# Altium schematic sheet Micro.SchDoc(Micro)
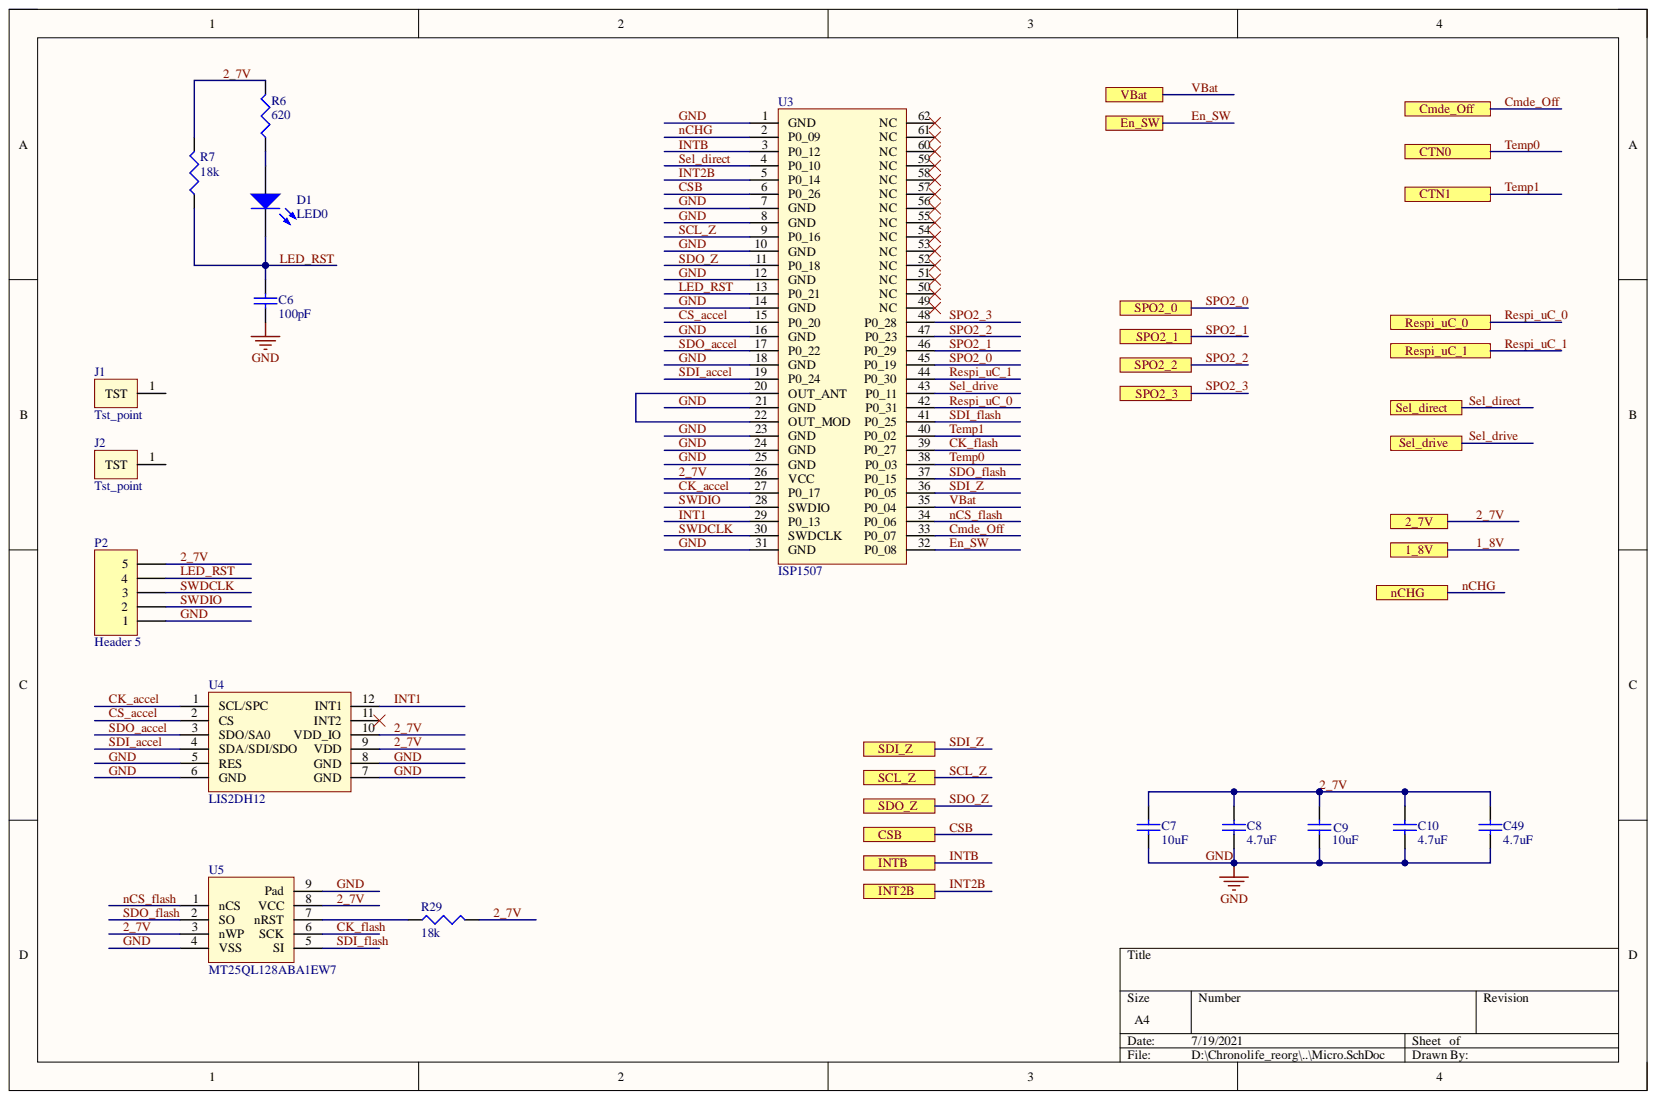
[diagram p0: Micro.SchDoc]

## Parts
C6  100pF  [0402_GC]
C7  10uF  [0402_GC]
C8  4.7uF  [0402_GC]
C9  10uF  [0402_GC]
C10  4.7uF  [0402_GC]
C49  4.7uF  [0402_GC]
D1  LED0  [0603D_GC]
J1  Tst_point  [Mire]
J2  Tst_point  [Mire]
P2  Header 5  [5PADRb_G]
R6  620  [0402_GC]
R7  18k  [0402_GC]
R29  18k  [0402_GC]
U3  ISP1507  [ISP1507]
U4  LIS2DH12  [LGA_12_GC]
U5  MT25QL128ABA1EW7  [WSON_8_8x6]

## Ports
1_8V, 2_7V, Cmde_Off, CSB, CTN0, CTN1, En_SW, INT2B, INTB, nCHG, Respi_uC_0, Respi_uC_1, SCL_Z, SDI_Z, SDO_Z, Sel_direct, Sel_drive, SPO2_0, SPO2_1, SPO2_2, SPO2_3, VBat

## Nets
2_7V: C7.2 C8.2 C9.2 C10.2 C49.2 P2.5 R6.2 R7.2 R29.2 U3.26(VCC) U4.9(VDD) U4.10(VDD_IO) U5.3(nWP) U5.8(VCC) ; port 2_7V
CK_accel: U3.27(P0_17) U4.1(SCL/SPC)
CK_flash: U3.39(P0_27) U5.6(SCK)
Cmde_Off: U3.33(P0_07) ; port Cmde_Off
CS_accel: U3.15(P0_20) U4.2(CS)
CSB: U3.6(P0_26) ; port CSB
En_SW: U3.32(P0_08) ; port En_SW
GND: C6.1 C7.1 C8.1 C9.1 C10.1 C49.1 P2.1 U3.1(GND) U3.7(GND) U3.8(GND) U3.10(GND) U3.12(GND) U3.14(GND) U3.16(GND) U3.18(GND) U3.21(GND) U3.23(GND) U3.24(GND) U3.25(GND) U3.31(GND) U4.5(RES) U4.6(GND) U4.7(GND) U4.8(GND) U5.4(VSS) U5.9(Pad)
INT1: U3.29(P0_13) U4.12(INT1)
INT2B: U3.5(P0_14) ; port INT2B
INTB: U3.3(P0_12) ; port INTB
LED_RST: C6.2 D1.2 P2.4 R7.1 U3.13(P0_21)
nCHG: U3.2(P0_09) ; port nCHG
nCS_flash: U3.34(P0_06) U5.1(nCS)
Respi_uC_0: U3.42(P0_31) ; port Respi_uC_0
Respi_uC_1: U3.44(P0_30) ; port Respi_uC_1
SCL_Z: U3.9(P0_16) ; port SCL_Z
SDI_accel: U3.19(P0_24) U4.4(SDA/SDI/SDO)
SDI_flash: U3.41(P0_25) U5.5(SI)
SDI_Z: U3.36(P0_05) ; port SDI_Z
SDO_accel: U3.17(P0_22) U4.3(SDO/SA0)
SDO_flash: U3.37(P0_15) U5.2(SO)
SDO_Z: U3.11(P0_18) ; port SDO_Z
Sel_direct: U3.4(P0_10) ; port Sel_direct
Sel_drive: U3.43(P0_11) ; port Sel_drive
SPO2_0: U3.45(P0_19) ; port SPO2_0
SPO2_1: U3.46(P0_29) ; port SPO2_1
SPO2_2: U3.47(P0_23) ; port SPO2_2
SPO2_3: U3.48(P0_28) ; port SPO2_3
SWDCLK: P2.3 U3.30(SWDCLK)
SWDIO: P2.2 U3.28(SWDIO)
Temp0: U3.38(P0_03) ; port CTN0
Temp1: U3.40(P0_02) ; port CTN1
VBat: U3.35(P0_04) ; port VBat
NetD1_1: D1.1 R6.1
NetR29_1: R29.1 U5.7(nRST)
NetU3_20: U3.20(OUT_ANT) U3.22(OUT_MOD)
1_8V: ; port 1_8V

Not connected: J1 1; J2 1; U3 49(NC) 50(NC) 51(NC) 52(NC) 53(NC) 54(NC) 55(NC) 56(NC) 57(NC) 58(NC) 59(NC) 60(NC) 61(NC) 62(NC); U4 11(INT2)

## Library data of the parts
C6-C10 C49 = Cap: Capacitor; Component Kind: Standard; LatestRevisionDate: 17-Jul-2002; LatestRevisionNote: Re-released for DXP Platform.; Library Name: Miscellaneous Devices.IntLib; PackageDescription: Radial Cap, Thru-Hole; 2 Leads; 0.3 in Pin Spacing; PackageReference: RAD-0.3; Published: 8-Jun-2000; Signal Integrity: Cap; Simulation: CAP; Publisher: Altium Limited
  C6: Value: 100pF
  C7 C9: Value: 10uF
  C8 C10 C49: Value: 4.7uF
D1 = LED0: Typical INFRARED GaAs LED; Component Kind: Standard; LatestRevisionDate: 08-Jul-2005; LatestRevisionNote: Mech Layer 1 removed.; Library Name: Miscellaneous Devices.IntLib; PackageDescription: LED; 2 Leads; PackageReference: LED-0; Published: 8-Jun-2000; Simulation: LED0; Publisher: Altium Limited
P2 = Header 5: Header, 5-Pin; Component Kind: Standard; LatestRevisionDate: 17-Jul-2002; LatestRevisionNote: Re-released for DXP Platform.; Library Name: Miscellaneous Connectors.IntLib; Signal Integrity: Connector; Publisher: Altium Limited
R6 R7 R29 = Res3: Resistor; Component Kind: Standard; LatestRevisionDate: 29-May-2009; LatestRevisionNote: IPC-7351 Footprint Added.; Library Name: Miscellaneous Devices.IntLib; PackageDescription: Chip Resistor; PackageReference: J1-0603; Published: 8-Jun-2000; Signal Integrity: Res; Simulation: RESISTOR; Publisher: Altium Limited
  R6: Value: 620
  R7 R29: Value: 18k
J1 J2 = Tst_point: Component Kind: Standard; Library Name: Components_0.SchLib
U3 = ISP1507: Component Kind: Standard; Library Name: Components_0.SchLib
U4 = LIS2DH12: Component Kind: Standard; Library Name: Components_0.SchLib
U5 = MT25QL128ABA1EW7: Component Kind: Standard; Library Name: Components_0.SchLib
